# Altium schematic sheet Contactos.SchDoc
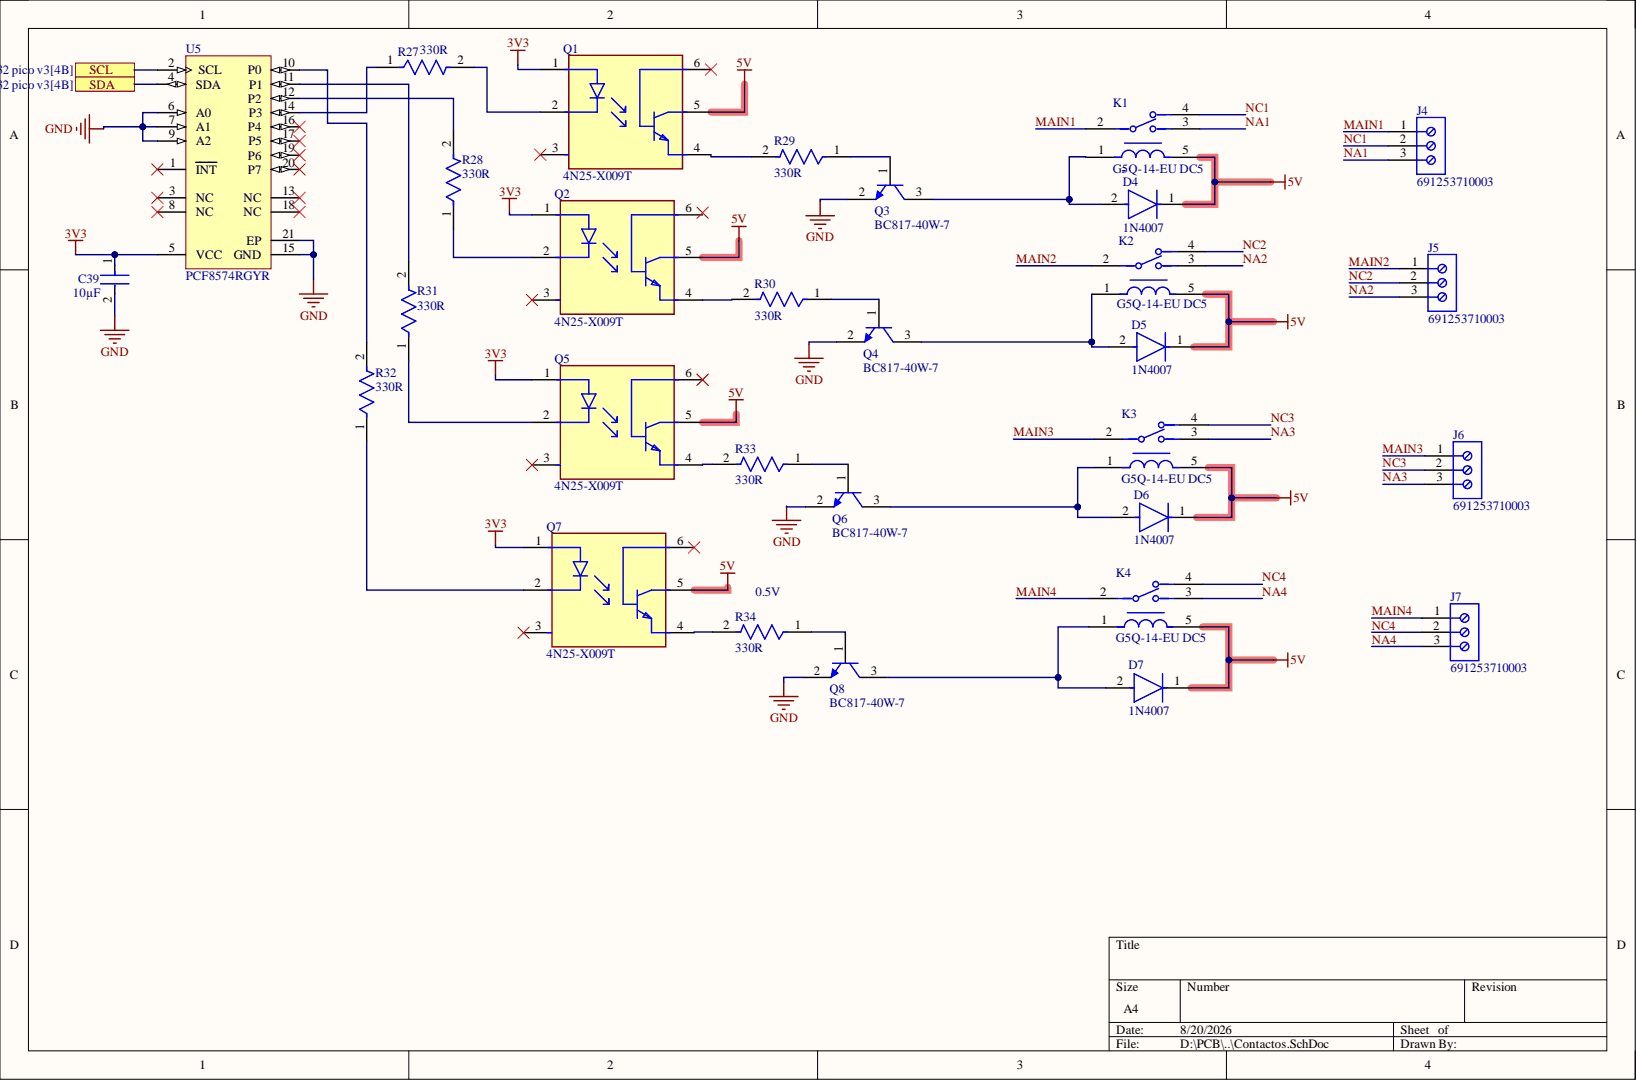
[diagram p0: Contactos.SchDoc]

## Parts
C39  Capacitance: 10µF  [FP-CC0603-DC-MFG]  (hidden comment: CC0603KRX5R7BB106)
D4  1N4007  [FP-1N4007-MFG]
D5  1N4007  [FP-1N4007-MFG]
D6  1N4007  [FP-1N4007-MFG]
D7  1N4007  [FP-1N4007-MFG]
J4  691253710003  [691253710003]
J5  691253710003  [691253710003]
J6  691253710003  [691253710003]
J7  691253710003  [691253710003]
K1  G5Q-14-EU DC5  [FP-G5Q-14-EU_DC5-MFG]
K2  G5Q-14-EU DC5  [FP-G5Q-14-EU_DC5-MFG]
K3  G5Q-14-EU DC5  [FP-G5Q-14-EU_DC5-MFG]
K4  G5Q-14-EU DC5  [FP-G5Q-14-EU_DC5-MFG]
Q1  4N25-X009T  [FP-4N25-X009T-MFG]
Q2  4N25-X009T  [FP-4N25-X009T-MFG]
Q3  BC817-40W-7  [DIOD-SOT323-3_V]
Q4  BC817-40W-7  [DIOD-SOT323-3_V]
Q5  4N25-X009T  [FP-4N25-X009T-MFG]
Q6  BC817-40W-7  [DIOD-SOT323-3_V]
Q7  4N25-X009T  [FP-4N25-X009T-MFG]
Q8  BC817-40W-7  [DIOD-SOT323-3_V]
R27  Resistance: 330R  [FP-CRCW0603-e3-MFG]  (hidden comment: CRCW0603330RFKEA)
R28  Resistance: 330R  [FP-CRCW0603-e3-MFG]  (hidden comment: CRCW0603330RFKEA)
R29  Resistance: 330R  [FP-CRCW0603-e3-MFG]  (hidden comment: CRCW0603330RFKEA)
R30  Resistance: 330R  [FP-CRCW0603-e3-MFG]  (hidden comment: CRCW0603330RFKEA)
R31  Resistance: 330R  [FP-CRCW0603-e3-MFG]  (hidden comment: CRCW0603330RFKEA)
R32  Resistance: 330R  [FP-CRCW0603-e3-MFG]  (hidden comment: CRCW0603330RFKEA)
R33  Resistance: 330R  [FP-CRCW0603-e3-MFG]  (hidden comment: CRCW0603330RFKEA)
R34  Resistance: 330R  [FP-CRCW0603-e3-MFG]  (hidden comment: CRCW0603330RFKEA)
U5  PCF8574RGYR  [RGY0020A_V]

## Ports
SCL, SDA

## Nets
3V3: C39.1 Q1.1 Q2.1 Q5.1 Q7.1 U5.5(VCC)
5V: D4.1 D5.1 D6.1 D7.1 K1.5 K2.5 K3.5 K4.5 Q1.5 Q2.5 Q5.5 Q7.5
GND: C39.2 Q3.2 Q4.2 Q6.2 Q8.2 U5.6(A0) U5.7(A1) U5.9(A2) U5.15(GND) U5.21(EP)
MAIN1: J4.1 K1.2
MAIN2: J5.1 K2.2
MAIN3: J6.1 K3.2
MAIN4: J7.1 K4.2
NA1: J4.3 K1.3
NA2: J5.3 K2.3
NA3: J6.3 K3.3
NA4: J7.3 K4.3
NC1: J4.2 K1.4
NC2: J5.2 K2.4
NC3: J6.2 K3.4
NC4: J7.2 K4.4
SCL: U5.2(SCL) ; port SCL
SDA: U5.4(SDA) ; port SDA
NetD4_2: D4.2 K1.1 Q3.3
NetD5_2: D5.2 K2.1 Q4.3
NetD6_2: D6.2 K3.1 Q6.3
NetD7_2: D7.2 K4.1 Q8.3
NetQ1_2: Q1.2 R27.2
NetQ1_4: Q1.4 R29.2
NetQ2_2: Q2.2 R28.1
NetQ2_4: Q2.4 R30.2
NetQ3_1: Q3.1 R29.1
NetQ4_1: Q4.1 R30.1
NetQ5_2: Q5.2 R31.1
NetQ5_4: Q5.4 R33.2
NetQ6_1: Q6.1 R33.1
NetQ7_2: Q7.2 R32.1
NetQ7_4: Q7.4 R34.2
NetQ8_1: Q8.1 R34.1
NetR27_1: R27.1 U5.14(P3)
NetR28_2: R28.2 U5.12(P2)
NetR31_2: R31.2 U5.11(P1)
NetR32_2: R32.2 U5.10(P0)

Not connected: Q1 3(NC) 6; Q2 3(NC) 6; Q5 3(NC) 6; Q7 3(NC) 6; U5 1(INT) 3(NC) 8(NC) 13(NC) 16(P4) 17(P5) 18(NC) 19(P6) 20(P7)

## Library data of the parts
C39 = CMP-00621-81932632-1: CC0603KRX5R7BB106 Multilayer Ceramic Capacitor, 10 uF, 16 V, ± 10%, X5R, 0603 [1608 Metric]; Voltage Rating: 16V; Case/Package: 0603; Component Kind: Standard; Dielectric: X5R; Halogen Free: Halogen Free; Height: 1mm; Lead Free: Lead Free; Library Name: Altium Content Vault; Material: Ceramic; Max Operating Temperature: 85°C; Min Operating Temperature: -55°C; NexarPartId: 81932632; RoHS: Non-Compliant; Tolerance: 10%; Voltage Rating (DC): 16V
D4-D7 = CMP-123542-000001-1: Rectifier diode 1000 V 1 A DO-41, ,; Manufacturer: Diotec; Capacitance: 15pF; Forward Current: 1A; Max Junction Temp: +175°C; Max Repetitive Reverse Voltage: 1000V; Max Surge Forward Current: 27A; Peak Reverse Current: 5uA; Value: 15pF; Automotive: No; Category: Diode; Component Kind: Standard; ComponentLink2Description: Datasheet; ComponentLink2URL: https://datasheet.octopart.com/1N4007-Diotec-datasheet-62346875.pdf; Device Class L1: Discrete Semiconductors; Device Class L2: Diodes; Device Class L3: Rectifier Diodes; Height: 2.8mm; Ipc Land Pattern Name: DIOAD888W100L520D280; Lead Free: Yes; Library Name: Altium Content Vault; Manufacturer Part Number: 1N4007; Octopart: https://octopart.com/1n4007-diotec-4040411; Package: 1N4007; Reverse Voltage: 1000V; RoHS: TRUE; Temperature: -50°C to +175°C; Temperature Range High: +175°C; Temperature Range Low: -50°C
J4-J7 = CMP-1502-02590-3: Terminal Block, 5.08MM Pitch, Horizontal, Modular, Rising Cage Clamp, 3PINS; Component Kind: Standard; Library Name: Altium Content Vault
K1-K4 = CMP-08822-000046-1: RELAY GEN PURPOSE SPDT 10A 5V; Coil Current: 80mA; Coil Power: 400mW; Coil Resistance: 63R; Coil Type: Non-Latching; Max Voltage Rating (AC): 277V; Max Voltage Rating (DC): 30V; Operate Time: 10ms; Relay Type: General Purpose; Release Time: 5ms; Coil Voltage (DC): 5V; Component Kind: Standard; Contact Current Rating: 10A; Contact Material: Silver; Depth: 10.3mm; Length: 20.3mm; Library Name: Altium Content Vault; Max Operating Temperature: 85°C; Min Operating Temperature: -40°C; Mount: Through Hole; Number of Pins: 5; Package Quantity: 400; Packaging: Bulk; RoHS Compliant: Yes; Series: G5Q-EU; Termination: PC Pin; Throw Configuration: SPDT; Voltage Rating (AC): 277V
Q1 Q2 Q5 Q7 = CMP-00030-12292229-1: 4N25-X009T Optocoupler DC-IN 1-CH Transistor With Base DC-OUT 6-Pin PDIP SMD T/R; Collector Emitter Saturation Voltage: 500mV; Collector Emitter Voltage (VCEO): 70V; Current Transfer Ratio: 50%; Forward Current: 60mA; Forward Voltage: 1.36V; Max Collector Current: 100mA; Max Power Dissipation: 150mW; Number of Channels: 1; Output Current per Channel: 50mA; Reverse Breakdown Voltage: 6V; Case/Package: SMD/SMT; Component Kind: Standard; Contact Plating: Tin; Lead Free: Lead Free; Library Name: Altium Content Vault; Max Input Current: 60mA; Max Operating Temperature: 100°C; Max Output Voltage: 70V; Min Operating Temperature: -55°C; Mount: Surface Mount; NexarPartId: 12292229; Number of Elements: 1; Number of Pins: 6; Packaging: Tape & Reel (TR); Power Dissipation: 150mW; Radiation Hardening: No; RoHS: Compliant
Q3 Q4 Q6 Q8 = CMP-2000-05934-1: NPN Small Signal Transistor, 45 V, 500 mA, -65 to 150 degC, 3-Pin SOT323, RoHS, Tape and Reel; Brand: DiodesIncorporated; Collector- Base Voltage VCBO: 50V; Collector- Emitter Voltage VCEO Max: 45V; Collector-Emitter Saturation Voltage: 0.7V; Configuration: Single; DC Collector/Base Gain hfe Min: 250; Emitter- Base Voltage VEBO: 5V; Gain Bandwidth Product fT: 100MHz; Maximum DC Collector Current: 1A; Pd - Power Dissipation: 200mW; Unit Weight: 0.000282oz; Component Kind: Standard; Datasheet URL: http://www.diodes.com/_files/datasheets/ds30575.pdf; Datasheet Version: Rev. 5-2, 01/2014; Factory Pack Quantity: 3000; Height: 1mm; Length: 2.2mm; Library Name: Altium Content Vault; Manufacturer: DiodesIncorporated; Manufacturer URL: http://www.diodes.com/; Maximum Operating Temperature: +150C; Minimum Operating Temperature: -65C; Mounting Style: SMD/SMT; Mounting Technology: SurfaceMount; Package / Case: SOT-23; Package Description: 3-Pin Small Outline Transistor, Body 2 x 1.25 mm, Pitch 0.65 mm; Package Reference: SOT323; Packaging: Reel; Product Category: BipolarTransistors-BJT; RoHS: True; Series: BC817; Transistor Polarity: NPN; Width: 1.35mm
R27-R34 = CMP-1012-00454-3: RES Thick Film, 330Ω, 1%, 0.1W, 100ppm/°C, 0603; Max Power Dissipation: 100mW; Voltage Rating: 75V; Case Code (Imperial): 0603; Case Code (Metric): 1608; Case/Package: 0603; Component Kind: Standard; Composition: Thick Film; Contact Plating: Tin; Depth: 0.85mm; Features: Automotive AEC-Q200; Height: 0.45mm; Lead Free: Lead Free; Length: 1.55mm; Library Name: Altium Content Vault; Max Operating Temperature: 155°C; Military Standard: Not; Min Operating Temperature: -55°C; Mount: Surface Mount; Number of Terminations: 2; Package Quantity: 10; Packaging: Tape and Reel; Power Rating: 100mW; Radiation Hardening: No; Temperature Coefficient: 100ppm/°C; Termination: SMD/SMT; Tolerance: 1%; Voltage Rating (DC): 75V; Weight: 7.1E-05oz; Width: 0.033inch
U5 = CMP-0314-00026-2: Remote 8-Bit I/O Expander for I2C-Bus, 2.5 to 6 V, -40 to 85 degC, 20-pin VQFN (RGY), Green (RoHS & no Sb/Br); Manufacturer: Texas Instruments; # of I/Os: 8; fSCLK(Max)(MHz): 0.1; I2C Address: 0100 xxx; Interrupt Output: Yes; Max Frequency(kHz): 100; SubFamily: I2C IO Expander; Vcc range(V): 2.5 to 6.0; VCC(Max)(V): 6; VCC(Min)(V): 2.5; Voltage Nodes(V): 5, 3.3; Code_JEDEC: MO-241-BA; Component Kind: Standard; ComponentLink1Description: Datasheet; ComponentLink1URL: http://www.ti.com/general/docs/lit/getliterature.tsp?genericPartNumber=PCF8574&fileType=pdf; ComponentLink2Description: Package Specification; ComponentLink2URL: http://www.ti.com/litv/pdf/mpss024a; DatasheetVersion: SCPS068G; Eco-Plan: Green (RoHS & no Sb/Br); Library Name: Altium Content Vault; Mounting Technology: Surface Mount; PackageDescription: 20-Leads Plastic Quad Flatpack No-Lead, 3.5 x 4.5 x 0.9 mm Body, 0.5 mm Pitch; PackageReference: RGY0020A; PackageVersion: revI, Jun-2011; PartNumber: PCF8574RGYR; Push-Pull I/O Type: Yes; Reset Input: No
